# Altium schematic sheet interface_board.SchDoc(interface_board)
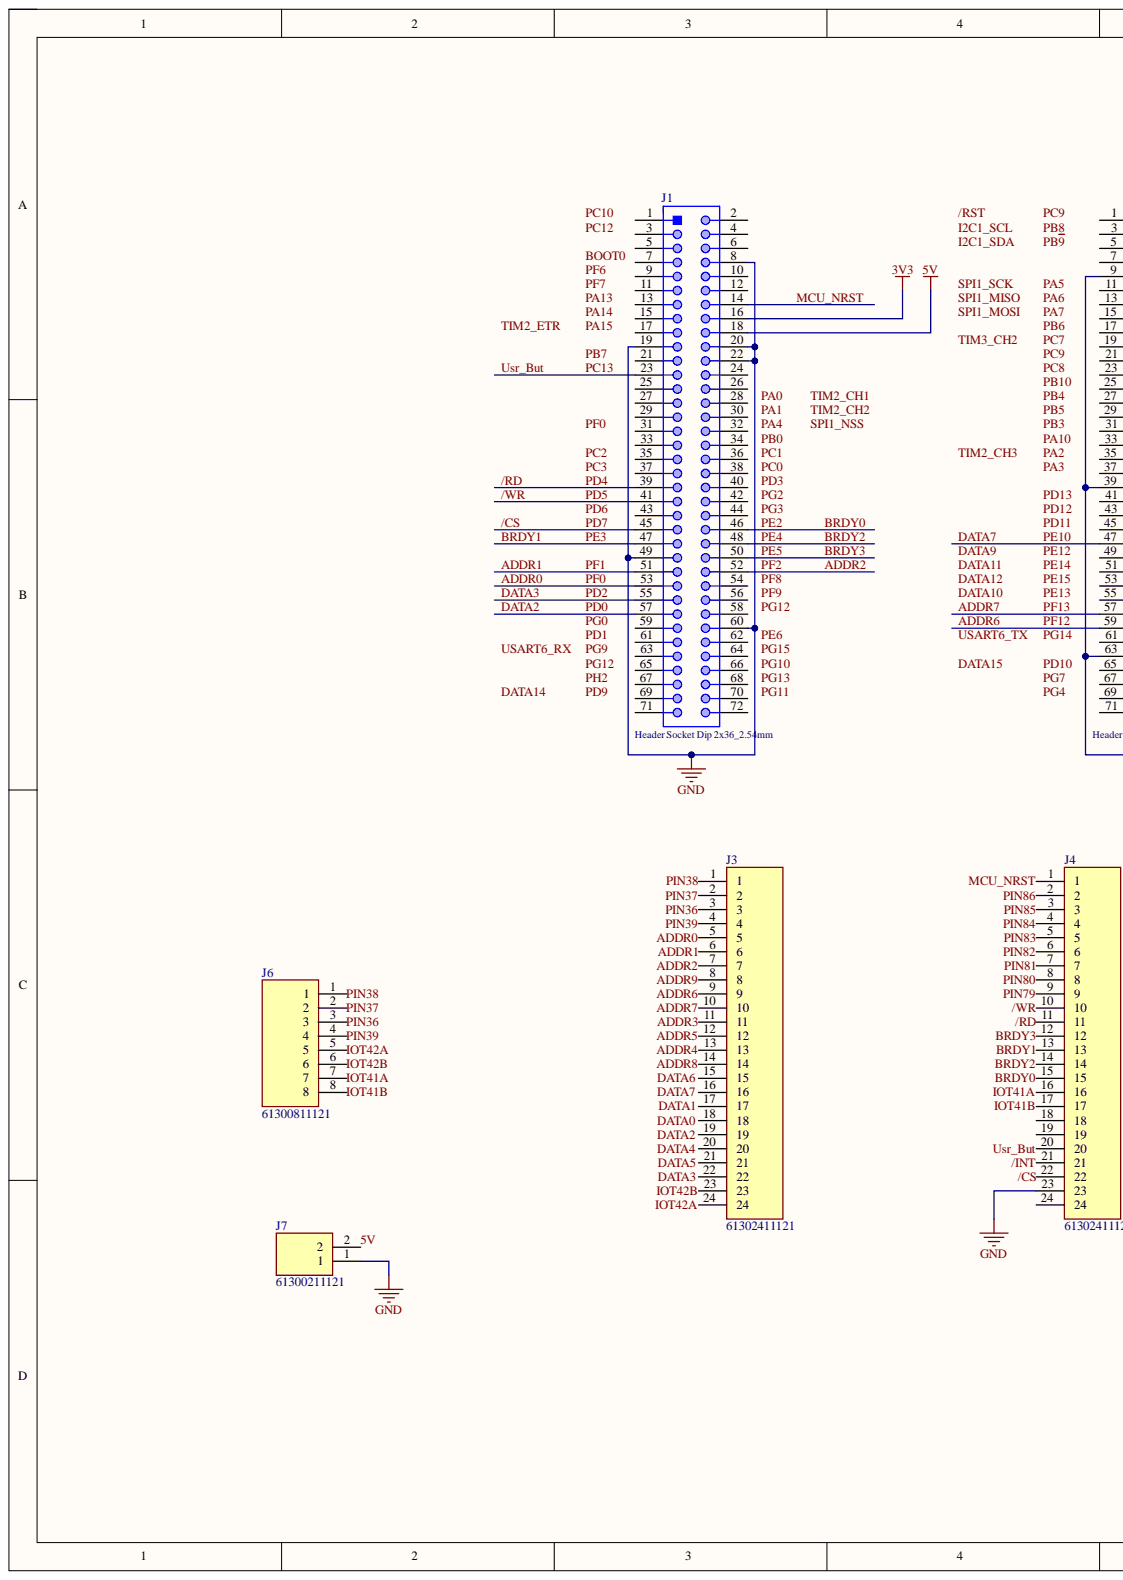
[diagram p0: interface_board.SchDoc, left]
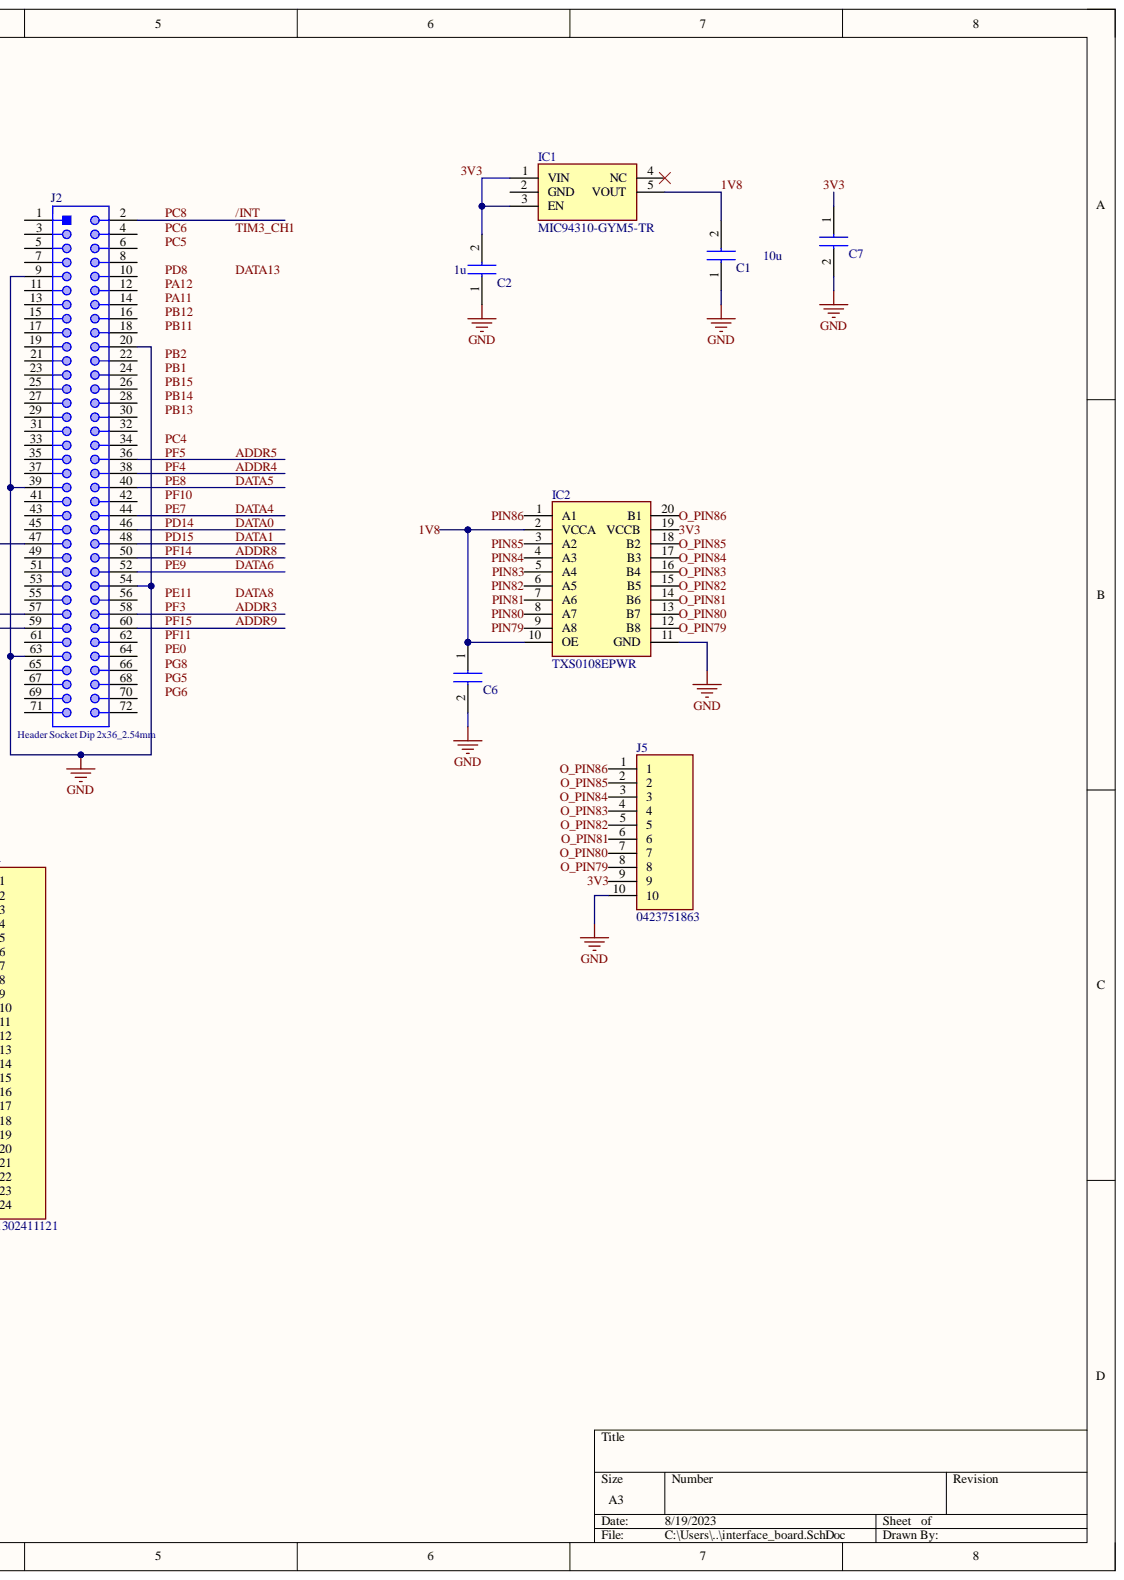
[diagram p1: interface_board.SchDoc, right]

## Parts
C1  value 10u  [CAPC1608X100N]  (hidden comment: CL10B106MQ8NRNC)
C2  value 1u  [C0603]  (hidden comment: C0603C105K9RACAUTO)
C6  C0603C104K9RAC7867  [CAPC1608X95N]  (value not drawn)
C7  C0603C104K9RAC7867  [CAPC1608X95N]  (value not drawn)
IC1  MIC94310-GYM5-TR  [SOT95P280X145-5N]
IC2  TXS0108EPWR  [SOP65P640X120-20N]
J1  Header Socket Dip 2x36_2.54mm  [IDC-2X35(SOCKET)]
J2  Header Socket Dip 2x36_2.54mm  [IDC-2X35(SOCKET)]
J3  61302411121  [HDRV24W64P0X254_1X24_6096X254X854P]
J4  61302411121  [HDRV24W64P0X254_1X24_6096X254X854P]
J5  0423751863  [HDRV10W87P0X254_1X10_2540X249X838P]
J6  61300811121  [HDRV8W64P0X254_1X8_2032X254X854P]
J7  61300211121  [HDRV2W110P0X254_1X2_508X254X854P]

## Nets
GND: C1.1 C2.1 C6.2 C7.2 IC2.11(GND) J1.8 J1.19 J1.20 J1.22 J1.49 J1.60 J2.9 J2.20 J2.39 J2.54 J2.63 J4.23 J5.10 J7.1
1V8: C1.2 C6.1 IC1.5(VOUT) IC2.2(VCCA) IC2.10(OE)
3V3: C2.2 C7.1 IC1.1(VIN) IC1.3(EN) IC2.19(VCCB) J1.16 J5.9
5V: J1.18 J7.2
/CS: J1.45 J4.22
/INT: J2.2 J4.21
/RD: J1.39 J4.11
/WR: J1.41 J4.10
ADDR0: J1.53 J3.5
ADDR1: J1.51 J3.6
ADDR2: J1.52 J3.7
ADDR3: J2.58 J3.11
ADDR4: J2.38 J3.13
ADDR5: J2.36 J3.12
ADDR6: J2.59 J3.9
ADDR7: J2.57 J3.10
ADDR8: J2.50 J3.14
ADDR9: J2.60 J3.8
BRDY0: J1.46 J4.15
BRDY1: J1.47 J4.13
BRDY2: J1.48 J4.14
BRDY3: J1.50 J4.12
DATA0: J2.46 J3.18
DATA1: J2.48 J3.17
DATA2: J1.57 J3.19
DATA3: J1.55 J3.22
DATA4: J2.44 J3.20
DATA5: J2.40 J3.21
DATA6: J2.52 J3.15
DATA7: J2.47 J3.16
IOT41A: J4.16 J6.7
IOT41B: J4.17 J6.8
IOT42A: J3.24 J6.5
IOT42B: J3.23 J6.6
MCU_NRST: J1.14 J4.1
O_PIN79: IC2.12(B8) J5.8
O_PIN80: IC2.13(B7) J5.7
O_PIN81: IC2.14(B6) J5.6
O_PIN82: IC2.15(B5) J5.5
O_PIN83: IC2.16(B4) J5.4
O_PIN84: IC2.17(B3) J5.3
O_PIN85: IC2.18(B2) J5.2
O_PIN86: IC2.20(B1) J5.1
PC13: J1.23 J4.20
PIN36: J3.3 J6.3
PIN37: J3.2 J6.2
PIN38: J3.1 J6.1
PIN39: J3.4 J6.4
PIN79: IC2.9(A8) J4.9
PIN80: IC2.8(A7) J4.8
PIN81: IC2.7(A6) J4.7
PIN82: IC2.6(A5) J4.6
PIN83: IC2.5(A4) J4.5
PIN84: IC2.4(A3) J4.4
PIN85: IC2.3(A2) J4.3
PIN86: IC2.1(A1) J4.2
/RST:
BOOT0:
DATA8:
DATA9:
DATA10:
DATA11:
DATA12:
DATA13:
DATA14:
DATA15:
I2C1_SCL:
I2C1_SDA:
PA0:
PA1:
PA2:
PA3:
PA4:
PA5:
PA6:
PA7:
PA10:
PA11:
PA12:
PA13:
PA14:
PA15:
PB0:
PB1:
PB2:
PB3:
PB4:
PB5:
PB6:
PB7:
PB8:
PB~{9}:
PB10:
PB11:
PB12:
PB13:
PB14:
PB15:
PC0:
PC1:
PC2:
PC3:
PC4:
PC5:
PC6:
PC7:
PC9:
PC10:
PC12:
PD1:
PD3:
PD6:
PD8:
PD9:
PD10:
PD11:
PD12:
PD13:
PE0:
PE6:
PE11:
PE12:
PE13:
PE14:
PE15:
PF6:
PF7:
PF8:
PF9:
PF10:
PF11:
PG0:
PG2:
PG3:
PG4:
PG5:
PG6:
PG7:
PG8:
PG9:
PG10:
PG11:
PG12:
PG13:
PG14:
PG15:
PH2:
SPI1_MISO:
SPI1_MOSI:
SPI1_NSS:
SPI1_SCK:
TIM2_CH1:
TIM2_CH2:
TIM2_CH3:
TIM2_ETR:
TIM3_CH1:
TIM3_CH2:
USART6_RX:
USART6_TX:

Not connected: IC1 2(GND) 4(NC); J1 1 2 3 4 5 6 7 9 10 11 12 13 15 17 21 24 25 26 27 28 29 30 31 32 33 34 35 36 37 38 40 42 43 44 54 56 58 59 61 62 63 64 65 66 67 68 69 70 71 72; J2 1 3 4 5 6 7 8 10 11 12 13 14 15 16 17 18 19 21 22 23 24 25 26 27 28 29 30 31 32 33 34 35 37 41 42 43 45 49 51 53 55 56 61 62 64 65 66 67 68 69 70 71 72; J4 18 19 24

## Library data of the parts
C1 = CL10B106MQ8NRNC: Capacitor; Manufacturer_Name: SAMSUNG; Arrow Part Number: CL10B106MQ8NRNC; Arrow Price/Stock: https://www.arrow.com/en/products/cl10b106mq8nrnc/samsung-electro-mechanics; Component Kind: Standard; Datasheet Link: https://altium.componentsearchengine.com/Datasheets/2/CL10B106MQ8NRNC.pdf; Height: 1mm; Manufacturer_Part_Number: CL10B106MQ8NRNC; Mouser Part Number: 187-CL10B106MQ8NRNC; Mouser Price/Stock: https://www.mouser.co.uk/ProductDetail/Samsung-Electro-Mechanics/CL10B106MQ8NRNC?qs=xZ%2FP%252Ba9zWqbER19NKQuT%2FA%3D%3D
C2 = C0603C105K9RACAUTO: Capacitor; Manufacturer_Name: KEMET; Arrow Part Number: C0603C105K9RACAUTO; Arrow Price/Stock: https://www.arrow.com/en/products/c0603c105k9racauto/kemet-corporation; Component Kind: Standard; Datasheet Link: https://content.kemet.com/datasheets/KEM_C1023_X7R_AUTO_SMD.pdf; Height: 0.87mm; Manufacturer_Part_Number: C0603C105K9RACAUTO; Mouser Part Number: 80-C0603C105K9RAUTO; Mouser Price/Stock: https://www.mouser.co.uk/ProductDetail/KEMET/C0603C105K9RACAUTO?qs=55YtniHzbhByKiCCYpi%252Brw%3D%3D
C6 C7 = C0603C104K9RAC7867: Capacitor; Manufacturer_Name: KEMET; Component Kind: Standard; Datasheet Link: https://content.kemet.com/datasheets/KEM_C1002_X7R_SMD.pdf; Height: 0.95mm; Manufacturer_Part_Number: C0603C104K9RAC7867; Mouser Part Number: N/A; Mouser Price/Stock: https://www.mouser.com/Search/Refine.aspx?Keyword=N%2FA
IC1 = MIC94310-GYM5-TR: Integrated Circuit; Manufacturer_Name: Microchip; Component Kind: Standard; Datasheet Link: https://ww1.microchip.com/downloads/en/DeviceDoc/MIC94310-Data-Sheet-20006105B.pdf; Height: 1.45mm; Library Name: SamacSys.SchLib; Manufacturer_Part_Number: MIC94310-GYM5-TR; Mouser Part Number: 998-MIC94310-GYM5TR; Mouser Price/Stock: https://www.mouser.co.uk/ProductDetail/Microchip-Technology/MIC94310-GYM5-TR?qs=U6T8BxXiZAU7YoBd%252BGzRaA%3D%3D
IC2 = TXS0108EPWR: Integrated Circuit; Manufacturer_Name: Texas Instruments; Arrow Part Number: TXS0108EPWR; Arrow Price/Stock: https://www.arrow.com/en/products/txs0108epwr/texas-instruments?region=nac; Component Kind: Standard; Datasheet Link: http://www.ti.com/lit/ds/symlink/txs0108e.pdf; Height: 1.2mm; Manufacturer_Part_Number: TXS0108EPWR; Mouser Part Number: 595-TXS0108EPWR; Mouser Price/Stock: https://www.mouser.co.uk/ProductDetail/Texas-Instruments/TXS0108EPWR?qs=IUbkBnfnSQLgiWtCbWtYOw%3D%3D
J1 J2 = Header Socket 2x36_2.54mm: THT Vertical Header Socket WR-PHD, Pitch 2.54 mm, Dual Row, 72 pins; Manufacturer: Wurth Elektronik; Part Number: 61307221121; Contact Resistance: 20mOhm; Gender: Male; Pin Number: 72; Pitch: 2.54mm; Rated Current: 3A; Withstanding Voltage: 500VAC; Working Voltage: 250VAC; Component Kind: Standard; Datasheet URL: https://katalog.we-online.de/em/datasheet/6130xx21121.pdf; Manufacturer URL: http://www.we-online.de; Mounting Technology: THT; Operating Temperature: -40to105degC; Orientation: Vertical; Package Reference: 61307221121; Packaging: Bag; Row Number: Dual; Library Name: Jaden_Lib.SchLib; Publisher: WurthElektronik
J3 J4 = 61302411121: Connector; Manufacturer_Name: Wurth Elektronik; Component Kind: Standard; Datasheet Link: https://www.digikey.es/es/datasheets/wurthelectronicsinc/wurth-electronics-inc-6130xx11121; Height: 8.54mm; Manufacturer_Part_Number: 61302411121; Mouser Part Number: 710-61302411121; Mouser Price/Stock: https://www.mouser.co.uk/ProductDetail/Wurth-Elektronik/61302411121?qs=PhR8RmCirEbMl%2Fl9%2FR2aXg%3D%3D
J5 = 0423751863: Connector; Manufacturer_Name: Molex; Arrow Part Number: 0423751863; Arrow Price/Stock: https://www.arrow.com/en/products/0423751863/molex; Component Kind: Standard; Datasheet Link: https://www.molex.com/pdm_docs/sd/423751863_sd.pdf; Height: 8.38mm; Manufacturer_Part_Number: 0423751863; Mouser Part Number: N/A; Mouser Price/Stock: https://www.mouser.co.uk/ProductDetail/Molex/0423751863?qs=%252BdQmOuGyFcEDjezqqbZoaA%3D%3D
J6 = 61300811121: Connector; Manufacturer_Name: Wurth Elektronik; Component Kind: Standard; Datasheet Link: http://katalog.we-online.de/em/datasheet/6130xx11121.pdf; Height: 8.54mm; Manufacturer_Part_Number: 61300811121; Mouser Part Number: 710-61300811121; Mouser Price/Stock: https://www.mouser.co.uk/ProductDetail/Wurth-Elektronik/61300811121?qs=PhR8RmCirEZRdx%252BVlEmRRA%3D%3D
J7 = 61300211121: Connector; Manufacturer_Name: Wurth Elektronik; Component Kind: Standard; Datasheet Link: https://componentsearchengine.com/Datasheets/2/61300211121.pdf; Height: 8.54mm; Manufacturer_Part_Number: 61300211121; Mouser Part Number: 710-61300211121; Mouser Price/Stock: https://www.mouser.co.uk/ProductDetail/Wurth-Elektronik/61300211121?qs=t4813l51qx%252B1A5GYDQxPlw%3D%3D
